# Altium schematic sheet board_sheet4.SchDoc
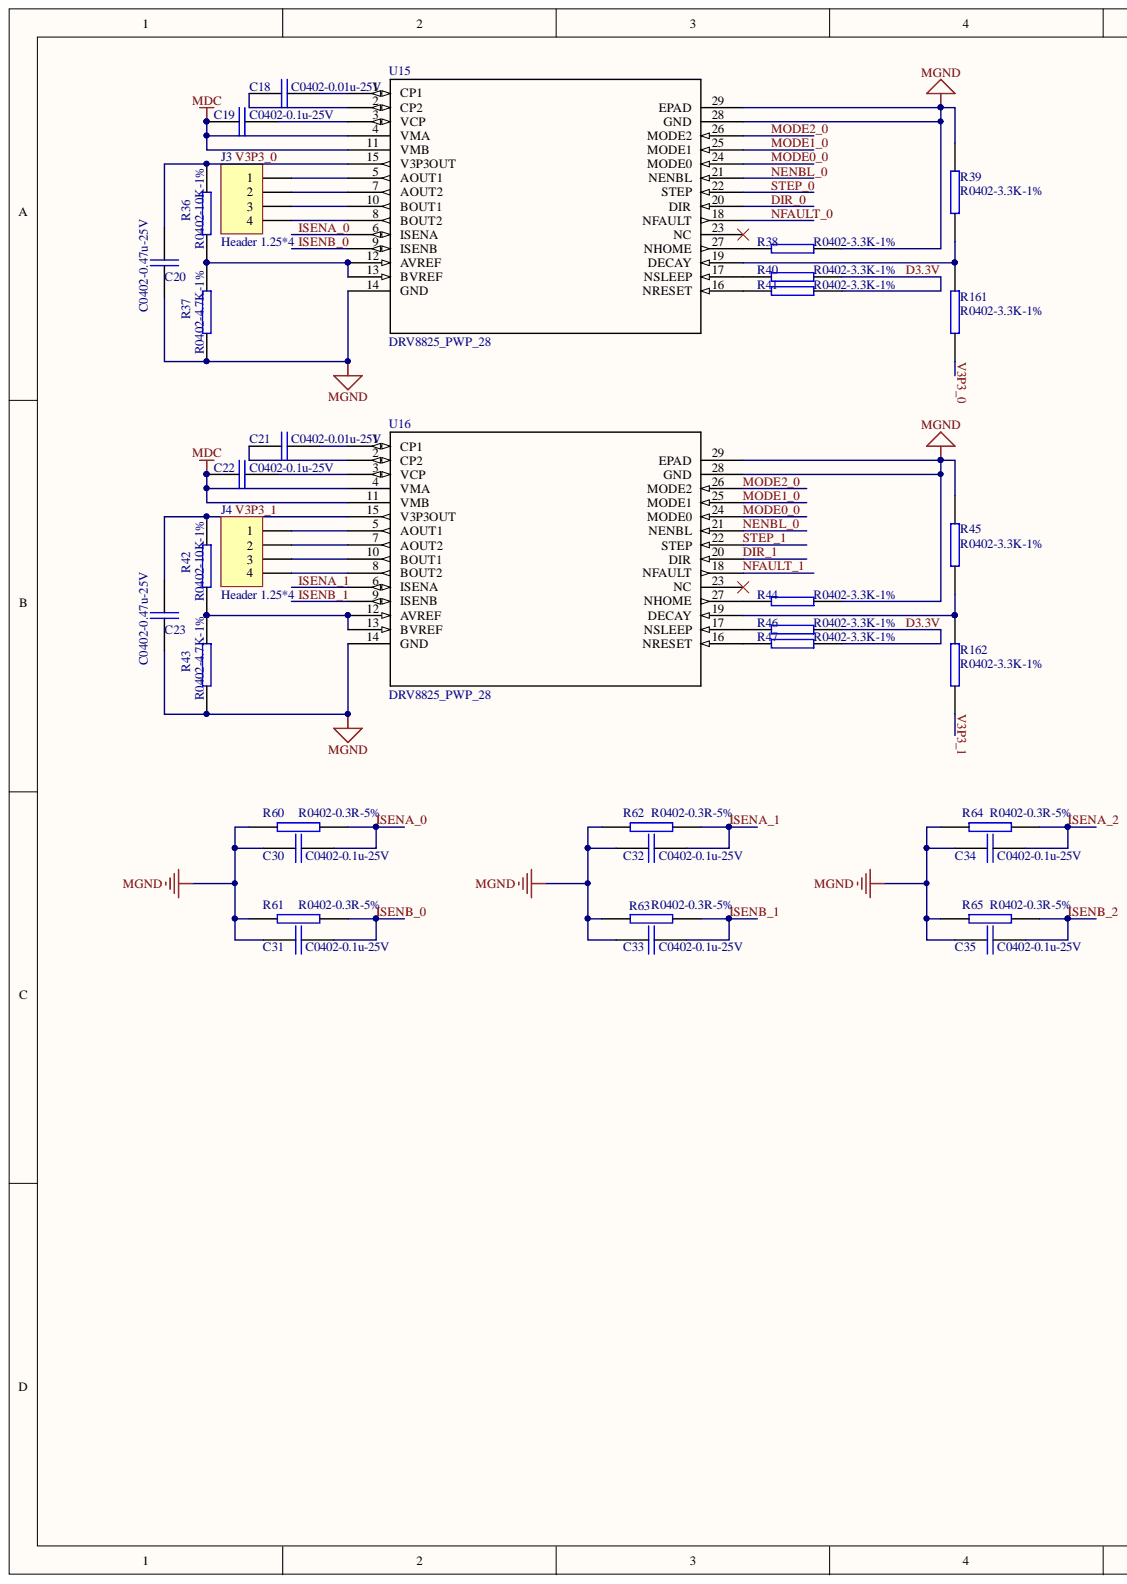
[diagram p0: board_sheet4.SchDoc, left]
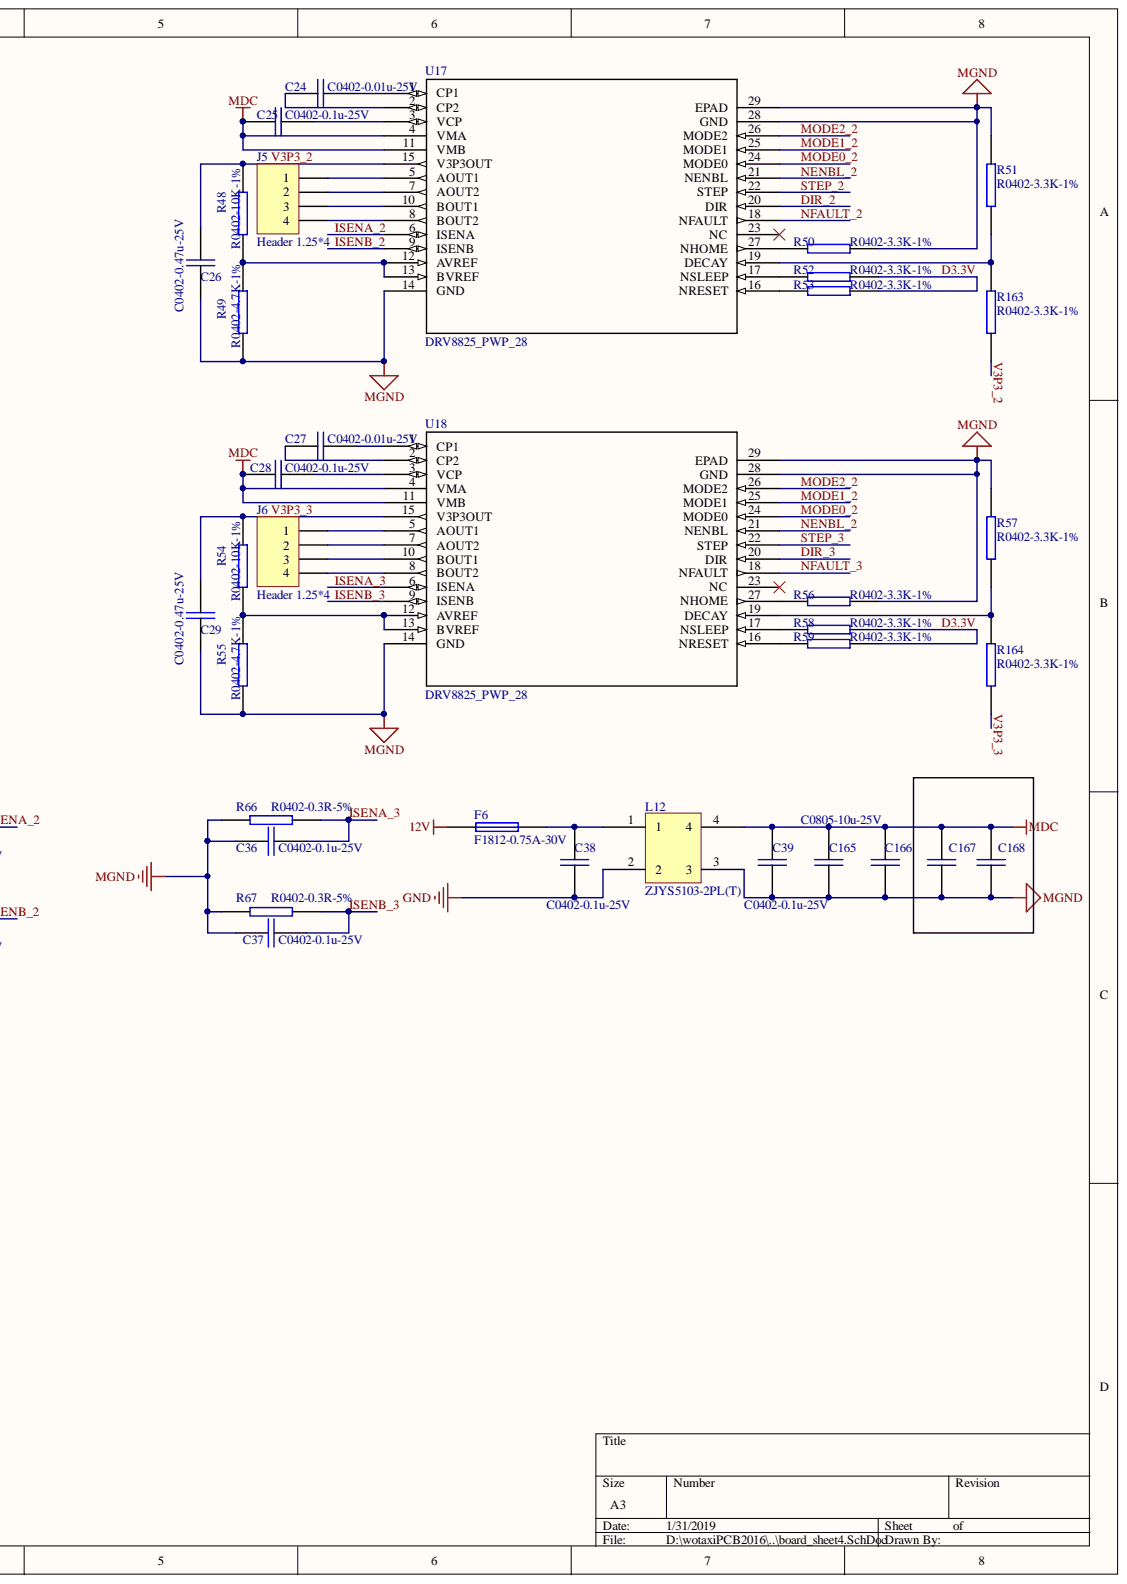
[diagram p1: board_sheet4.SchDoc, right]

## Parts
C18  C0402-0.01u-25V  [0402]
C19  C0402-0.1u-25V  [0402]
C20  C0402-0.47u-25V  [0402]
C21  C0402-0.01u-25V  [0402]
C22  C0402-0.1u-25V  [0402]
C23  C0402-0.47u-25V  [0402]
C24  C0402-0.01u-25V  [0402]
C25  C0402-0.1u-25V  [0402]
C26  C0402-0.47u-25V  [0402]
C27  C0402-0.01u-25V  [0402]
C28  C0402-0.1u-25V  [0402]
C29  C0402-0.47u-25V  [0402]
C30  C0402-0.1u-25V  [0402]
C31  C0402-0.1u-25V  [0402]
C32  C0402-0.1u-25V  [0402]
C33  C0402-0.1u-25V  [0402]
C34  C0402-0.1u-25V  [0402]
C35  C0402-0.1u-25V  [0402]
C36  C0402-0.1u-25V  [0402]
C37  C0402-0.1u-25V  [0402]
C38  C0402-0.1u-25V  [0402]
C39  C0402-0.1u-25V  [0402]
C165  C0805-10u-25V  [0805]
C166  C0805-10u-25V  [0805]
C167  C0805-10u-25V  [0805]
C168  C0805-10u-25V  [0805]
F6  F1812-0.75A-30V  [C4532-1812]
J3  Header 1.25*4  [TX-4*1.25-A]
J4  Header 1.25*4  [TX-4*1.25-A]
J5  Header 1.25*4  [TX-4*1.25-A]
J6  Header 1.25*4  [TX-4*1.25-A]
L12  ZJYS5103-2PL(T)  [ZJYS5103]
R36  R0402-10K-1%  [0402]
R37  R0402-4.7K-1%  [0402]
R38  R0402-3.3K-1%  [0402]
R39  R0402-3.3K-1%  [0402]
R40  R0402-3.3K-1%  [0402]
R41  R0402-3.3K-1%  [0402]
R42  R0402-10K-1%  [0402]
R43  R0402-4.7K-1%  [0402]
R44  R0402-3.3K-1%  [0402]
R45  R0402-3.3K-1%  [0402]
R46  R0402-3.3K-1%  [0402]
R47  R0402-3.3K-1%  [0402]
R48  R0402-10K-1%  [0402]
R49  R0402-4.7K-1%  [0402]
R50  R0402-3.3K-1%  [0402]
R51  R0402-3.3K-1%  [0402]
R52  R0402-3.3K-1%  [0402]
R53  R0402-3.3K-1%  [0402]
R54  R0402-10K-1%  [0402]
R55  R0402-4.7K-1%  [0402]
R56  R0402-3.3K-1%  [0402]
R57  R0402-3.3K-1%  [0402]
R58  R0402-3.3K-1%  [0402]
R59  R0402-3.3K-1%  [0402]
R60  R0402-0.3R-5%  [0402]
R61  R0402-0.3R-5%  [0402]
R62  R0402-0.3R-5%  [0402]
R63  R0402-0.3R-5%  [0402]
R64  R0402-0.3R-5%  [0402]
R65  R0402-0.3R-5%  [0402]
R66  R0402-0.3R-5%  [0402]
R67  R0402-0.3R-5%  [0402]
R161  R0402-3.3K-1%  [0402]
R162  R0402-3.3K-1%  [0402]
R163  R0402-3.3K-1%  [0402]
R164  R0402-3.3K-1%  [0402]
U15  DRV8825_PWP_28  [PWP28_5P18X3P1]
U16  DRV8825_PWP_28  [PWP28_5P18X3P1]
U17  DRV8825_PWP_28  [PWP28_5P18X3P1]
U18  DRV8825_PWP_28  [PWP28_5P18X3P1]

## Nets
12V: F6.1
GND: C38.2 L12.2
MDC: C19.1 C22.1 C25.1 C28.1 C39.1 C165.1 C166.1 C167.1 C168.1 L12.4 U15.4(VMA) U15.11(VMB) U16.4(VMA) U16.11(VMB) U17.4(VMA) U17.11(VMB) U18.4(VMA) U18.11(VMB)
MGND: C20.2 C23.2 C26.2 C29.2 C30.2 C31.2 C32.2 C33.2 C34.2 C35.2 C36.2 C37.2 C39.2 C165.2 C166.2 C167.2 C168.2 L12.3 R37.1 R38.2 R39.2 R43.1 R44.2 R45.2 R49.1 R50.2 R51.2 R55.1 R56.2 R57.2 R60.2 R61.2 R62.2 R63.2 R64.2 R65.2 R66.2 R67.2 U15.14(GND) U15.28(GND) U15.29(EPAD) U16.14(GND) U16.28(GND) U16.29(EPAD) U17.14(GND) U17.28(GND) U17.29(EPAD) U18.14(GND) U18.28(GND) U18.29(EPAD)
D3.3V: R40.2 R41.2 R46.2 R47.2 R52.2 R53.2 R58.2 R59.2
DIR_0: U15.20(DIR)
DIR_1: U16.20(DIR)
DIR_2: U17.20(DIR)
DIR_3: U18.20(DIR)
ISENA_0: C30.1 R60.1 U15.6(ISENA)
ISENA_1: C32.1 R62.1 U16.6(ISENA)
ISENA_2: C34.1 R64.1 U17.6(ISENA)
ISENA_3: C36.1 R66.1 U18.6(ISENA)
ISENB_0: C31.1 R61.1 U15.9(ISENB)
ISENB_1: C33.1 R63.1 U16.9(ISENB)
ISENB_2: C35.1 R65.1 U17.9(ISENB)
ISENB_3: C37.1 R67.1 U18.9(ISENB)
MODE0_0: U15.24(MODE0) U16.24(MODE0)
MODE0_2: U17.24(MODE0) U18.24(MODE0)
MODE1_0: U15.25(MODE1) U16.25(MODE1)
MODE1_2: U17.25(MODE1) U18.25(MODE1)
MODE2_0: U15.26(MODE2) U16.26(MODE2)
MODE2_2: U17.26(MODE2) U18.26(MODE2)
NENBL_0: U15.21(NENBL) U16.21(NENBL)
NENBL_2: U17.21(NENBL) U18.21(NENBL)
NFAULT_0: U15.18(NFAULT)
NFAULT_1: U16.18(NFAULT)
NFAULT_2: U17.18(NFAULT)
NFAULT_3: U18.18(NFAULT)
STEP_0: U15.22(STEP)
STEP_1: U16.22(STEP)
STEP_2: U17.22(STEP)
STEP_3: U18.22(STEP)
V3P3_0: C20.1 R36.2 R161.1 U15.15(V3P3OUT)
V3P3_1: C23.1 R42.2 R162.1 U16.15(V3P3OUT)
V3P3_2: C26.1 R48.2 R163.1 U17.15(V3P3OUT)
V3P3_3: C29.1 R54.2 R164.1 U18.15(V3P3OUT)
NetC18_1: C18.1 U15.2(CP2)
NetC18_2: C18.2 U15.1(CP1)
NetC19_2: C19.2 U15.3(VCP)
NetC21_1: C21.1 U16.2(CP2)
NetC21_2: C21.2 U16.1(CP1)
NetC22_2: C22.2 U16.3(VCP)
NetC24_1: C24.1 U17.2(CP2)
NetC24_2: C24.2 U17.1(CP1)
NetC25_2: C25.2 U17.3(VCP)
NetC27_1: C27.1 U18.2(CP2)
NetC27_2: C27.2 U18.1(CP1)
NetC28_2: C28.2 U18.3(VCP)
NetC38_1: C38.1 F6.2 L12.1
NetJ3_1: J3.1 U15.5(AOUT1)
NetJ3_2: J3.2 U15.7(AOUT2)
NetJ3_3: J3.3 U15.10(BOUT1)
NetJ3_4: J3.4 U15.8(BOUT2)
NetJ4_1: J4.1 U16.5(AOUT1)
NetJ4_2: J4.2 U16.7(AOUT2)
NetJ4_3: J4.3 U16.10(BOUT1)
NetJ4_4: J4.4 U16.8(BOUT2)
NetJ5_1: J5.1 U17.5(AOUT1)
NetJ5_2: J5.2 U17.7(AOUT2)
NetJ5_3: J5.3 U17.10(BOUT1)
NetJ5_4: J5.4 U17.8(BOUT2)
NetJ6_1: J6.1 U18.5(AOUT1)
NetJ6_2: J6.2 U18.7(AOUT2)
NetJ6_3: J6.3 U18.10(BOUT1)
NetJ6_4: J6.4 U18.8(BOUT2)
NetR36_1: R36.1 R37.2 U15.12(AVREF) U15.13(BVREF)
NetR38_1: R38.1 U15.27(NHOME)
NetR39_1: R39.1 R161.2 U15.19(DECAY)
NetR40_1: R40.1 U15.17(NSLEEP)
NetR41_1: R41.1 U15.16(NRESET)
NetR42_1: R42.1 R43.2 U16.12(AVREF) U16.13(BVREF)
NetR44_1: R44.1 U16.27(NHOME)
NetR45_1: R45.1 R162.2 U16.19(DECAY)
NetR46_1: R46.1 U16.17(NSLEEP)
NetR47_1: R47.1 U16.16(NRESET)
NetR48_1: R48.1 R49.2 U17.12(AVREF) U17.13(BVREF)
NetR50_1: R50.1 U17.27(NHOME)
NetR51_1: R51.1 R163.2 U17.19(DECAY)
NetR52_1: R52.1 U17.17(NSLEEP)
NetR53_1: R53.1 U17.16(NRESET)
NetR54_1: R54.1 R55.2 U18.12(AVREF) U18.13(BVREF)
NetR56_1: R56.1 U18.27(NHOME)
NetR57_1: R57.1 R164.2 U18.19(DECAY)
NetR58_1: R58.1 U18.17(NSLEEP)
NetR59_1: R59.1 U18.16(NRESET)

Not connected: U15 23(NC); U16 23(NC); U17 23(NC); U18 23(NC)

## Library data of the parts
C18-C39 C165-C168 = CAP: Component Kind: Standard
  C18-C39 C165 C166: Library Name: KL300-POWER.SCHLIB
  C167 C168: Library Name: KL300-CONTROLER.SCHLIB
F6 = FUSE: Component Kind: Standard; Library Name: KL300-POWER.SCHLIB
J3-J6 = MHDR1X4: Component Kind: Standard; Library Name: KL300-POWER.SCHLIB
L12 = ZJYS5103-2PL: Component Kind: Standard; Library Name: KL300-POWER.SCHLIB
R36-R67 R161-R164 = RES: Component Kind: Standard
  R41 R47 R53 R59-R67: Library Name: KL300-POWER.SCHLIB
  R36-R40 R42-R46 R48-R52 R54-R58 R161-R164: Library Name: KL300-CONTROLER.SCHLIB
U15-U18 = DRV8825_PWP_28: Imported; Manufacturer: Texas Instruments; FAMILY_NAME: STEPPER DRIVER; INDUSTRY_STD_PKG_TYPE: HTSSOP; PACKAGE_DESIGNATOR: PWP; PIN_COUNT: 28; Component Kind: Standard; Datasheet URL: http://www.ti.com/lit/gpn/DRV8825; GENERIC_PART_NUMBER: DRV8825; Manufacturer_Part_Number: drv8825_pwp_28; RefDes: RefDes; Type: DEV; Value: Value; Vendor: Texas Instruments; Library Name: wtlDRV.SchLib
